# Altium schematic sheet IA Schematic.SchDoc("IA Schematic")
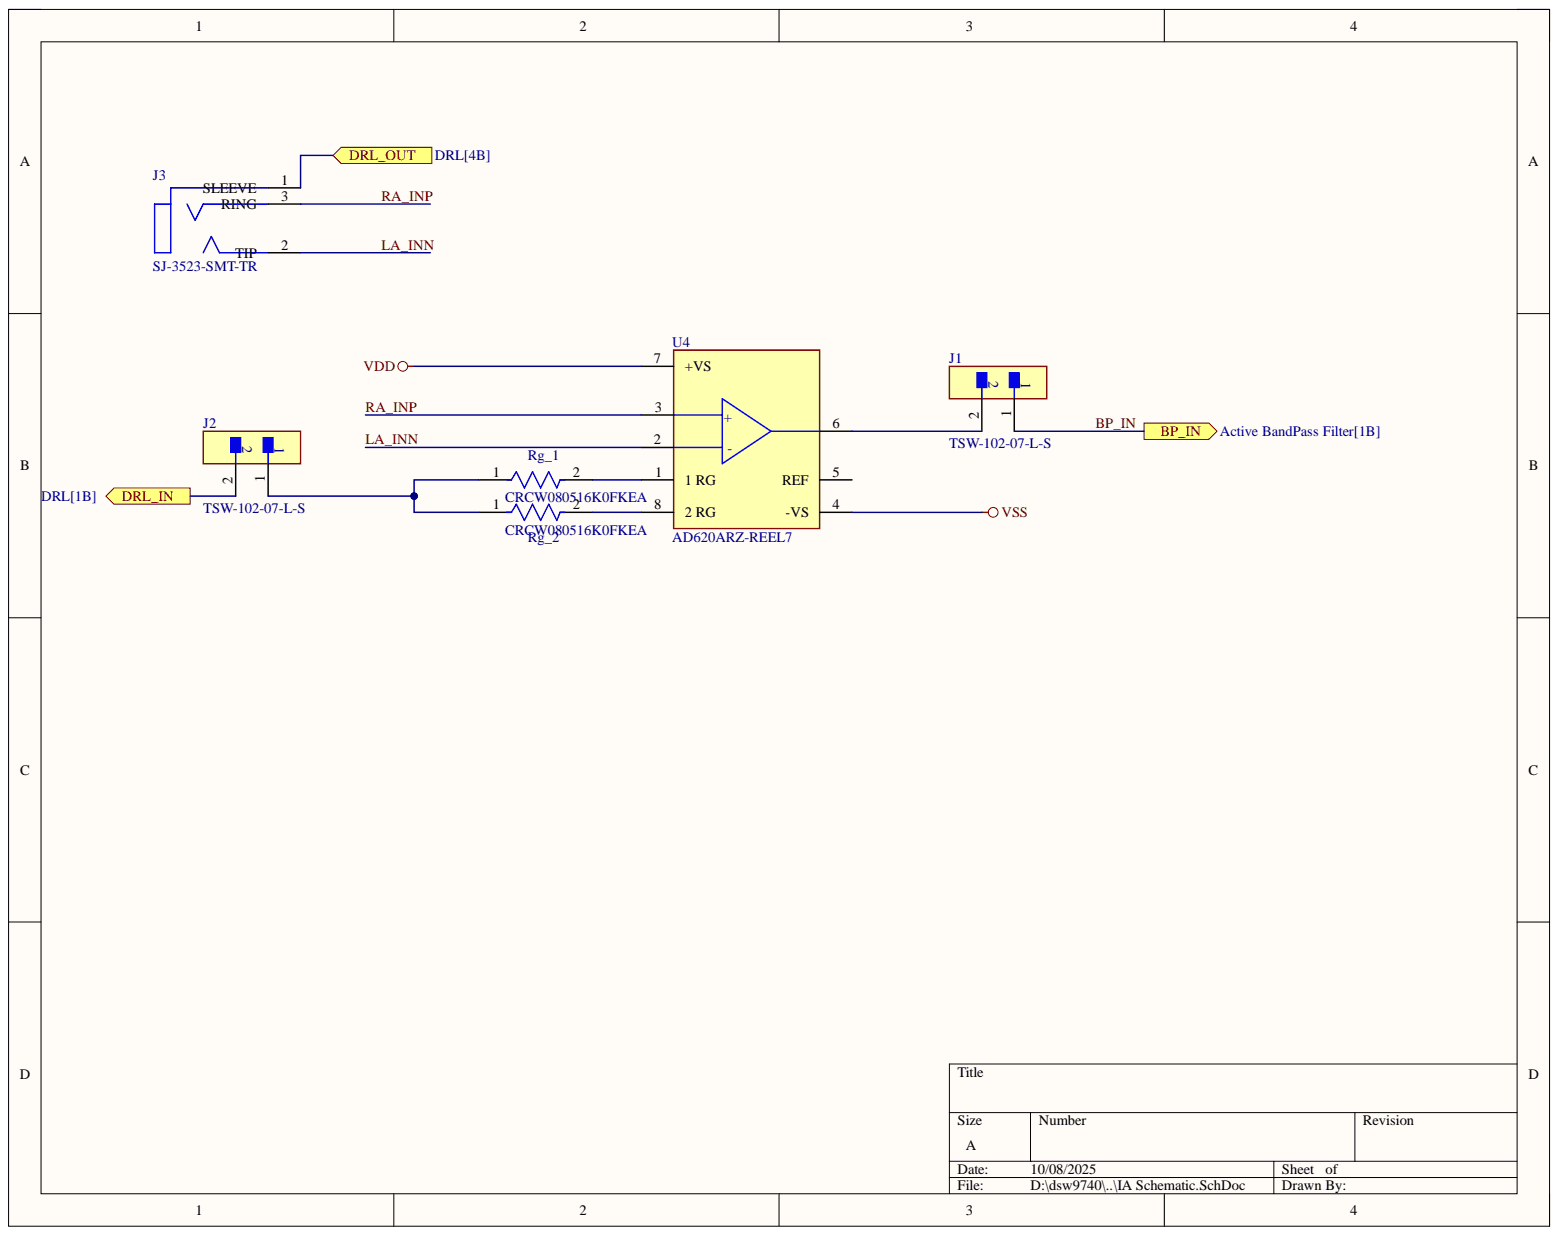
[diagram p0: IA Schematic.SchDoc]

## Parts
J1  TSW-102-07-L-S  [FP-TSW-102-07-L-S-MFG]
J2  TSW-102-07-L-S  [FP-TSW-102-07-L-S-MFG]
J3  SJ-3523-SMT-TR  [CUI_SJ-3523-SMT-TR]
Rg_1  CRCW080516K0FKEA  [FP-CRCW0805-e3-IPC_C]
Rg_2  CRCW080516K0FKEA  [FP-CRCW0805-e3-IPC_C]
U4  AD620ARZ-REEL7  [FP-R-8-IPC_B]

## Ports
BP_IN, DRL_IN, DRL_OUT

## Nets
VDD: U4.7(+VS)
VSS: U4.4(-VS)
BP_IN: J1.1 ; port BP_IN
DRL_IN: J2.2 ; port DRL_IN
DRL_OUT: J3.1 ; port DRL_OUT
LA_INN: J3.2 U4.2
RA_INP: J3.3 U4.3
NetJ1_2: J1.2 U4.6
NetJ2_1: J2.1 Rg_1.1 Rg_2.1
NetRg_1_2: Rg_1.2 U4.1(1_RG)
NetRg_2_2: Rg_2.2 U4.8(2_RG)

Not connected: U4 5(REF)

## Library data of the parts
J1 J2 = CMP-02766-001428-1: CONN HEADER VERT 2POS 2.54MM; Connector Type: Male Pin; Current Rating: 7.6A; Gender: Male; Max Voltage Rating (AC): 550V; Number of Contacts: 2; Color: Black; Component Kind: Standard; Contact Material: Bronze; Contact Mating Length: 0.23inch; Contact Plating: Gold; Contact Type: Male Pin; Depth: 2.54mm; Housing Color: Black; Housing Material: Polyester; Lead Free: Lead Free; Length: 5.08mm; Library Name: Altium Content Vault; Max Current Rating: 7.6A; Max Operating Temperature: 125°C; Min Operating Temperature: -55°C; Mount: Through Hole; Number of Positions: 2; Number of Rows: 1; Orientation: Straight; Package Quantity: 1; Packaging: Bulk; Pitch: 2.54mm; Radiation Hardening: No; REACH SVHC: No SVHC; RoHS Compliant: Yes; Series: TSW; Termination: Solder; Voltage Rating: 550V; Width: 2.54mm
J3 = SJ-3523-SMT-TR: 3.5 mm, Stereo, Right Angle, Surface Mount (SMT), 3 Conductors, 0 Internal Switches, Audio Jack Connector; MF: Same Sky; Check_prices: https://www.snapeda.com/parts/SJ-3523-SMT-TR/Same+Sky/view-part/?ref=eda; Component Kind: Standard; Library Name: SJ-3523-SMT-TR.SchLib; MP: SJ-3523-SMT-TR; Package: None; SnapEDA_Link: https://www.snapeda.com/parts/SJ-3523-SMT-TR/Same+Sky/view-part/?ref=snap
Rg_1 Rg_2 = CMP-2001-04979-2: RES Thick Film, 16kΩ, 1%, 0.125W, 100ppm/°C, 0805; Max Power Dissipation: 125mW; Resistance: 16kR; Voltage Rating: 150V; Case Code (Imperial): 0805; Case Code (Metric): 2012; Case/Package: 0805; Component Kind: Standard; Composition: Thick Film; Contact Plating: Tin; Depth: 1.25mm; Features: Automotive AEC-Q200; Height: 0.45mm; Lead Free: Lead Free; Length: 2mm; Library Name: Altium Content Vault; Max Operating Temperature: 155°C; Military Standard: Not; Min Operating Temperature: -55°C; Mount: Surface Mount; Number of Pins: 2; Number of Terminations: 2; Package Quantity: 10; Packaging: Tape and Reel; Power Rating: 125mW; Radiation Hardening: No; Temperature Coefficient: 100ppm/°C; Termination: SMD/SMT; Tolerance: 1%; Voltage Rating (DC): 150V; Weight: 0.000194oz; Width: 1.25mm
U4 = CMP-09116-000354-1: IC INST AMP 1 CIRCUIT 8SOIC; -3db Bandwidth: 1MHz; Bandwidth: 800kHz; Common Mode Rejection Ratio: 93dB; Dual Supply Voltage: 15V; Input Bias Current: 500pA; Input Offset Voltage (Vos): 30mV; Input Resistance: 10GR; Max Dual Supply Voltage: 18V; Max Power Dissipation: 650mW; Min Dual Supply Voltage: 2.3V; Min Supply Voltage: 4.6V; Nominal Supply Current: 900uA; Number of Channels: 1; Operating Supply Current: 1.3mA; Output Current per Channel: 18mA; Power Supply Rejection Ratio (PSRR): 140dB; Slew Rate: 1.2V/µs; Case/Package: SOIC; Component Kind: Standard; Contact Plating: Tin; Lead Free: Contains Lead; Library Name: Altium Content Vault; Max Operating Temperature: 125°C; Min Operating Temperature: -55°C; Mount: Surface Mount; Number of Circuits: 1; Number of Elements: 1; Number of Pins: 8; Operating Supply Voltage: 18V; Package Quantity: 1; Packaging: Tape and Reel; Power Dissipation: 650mW; Radiation Hardening: No; RoHS Compliant: Yes; Series: -; Supply Current: 900uA
